# Altium schematic sheet PWRMOD-001-RevA.SchDoc
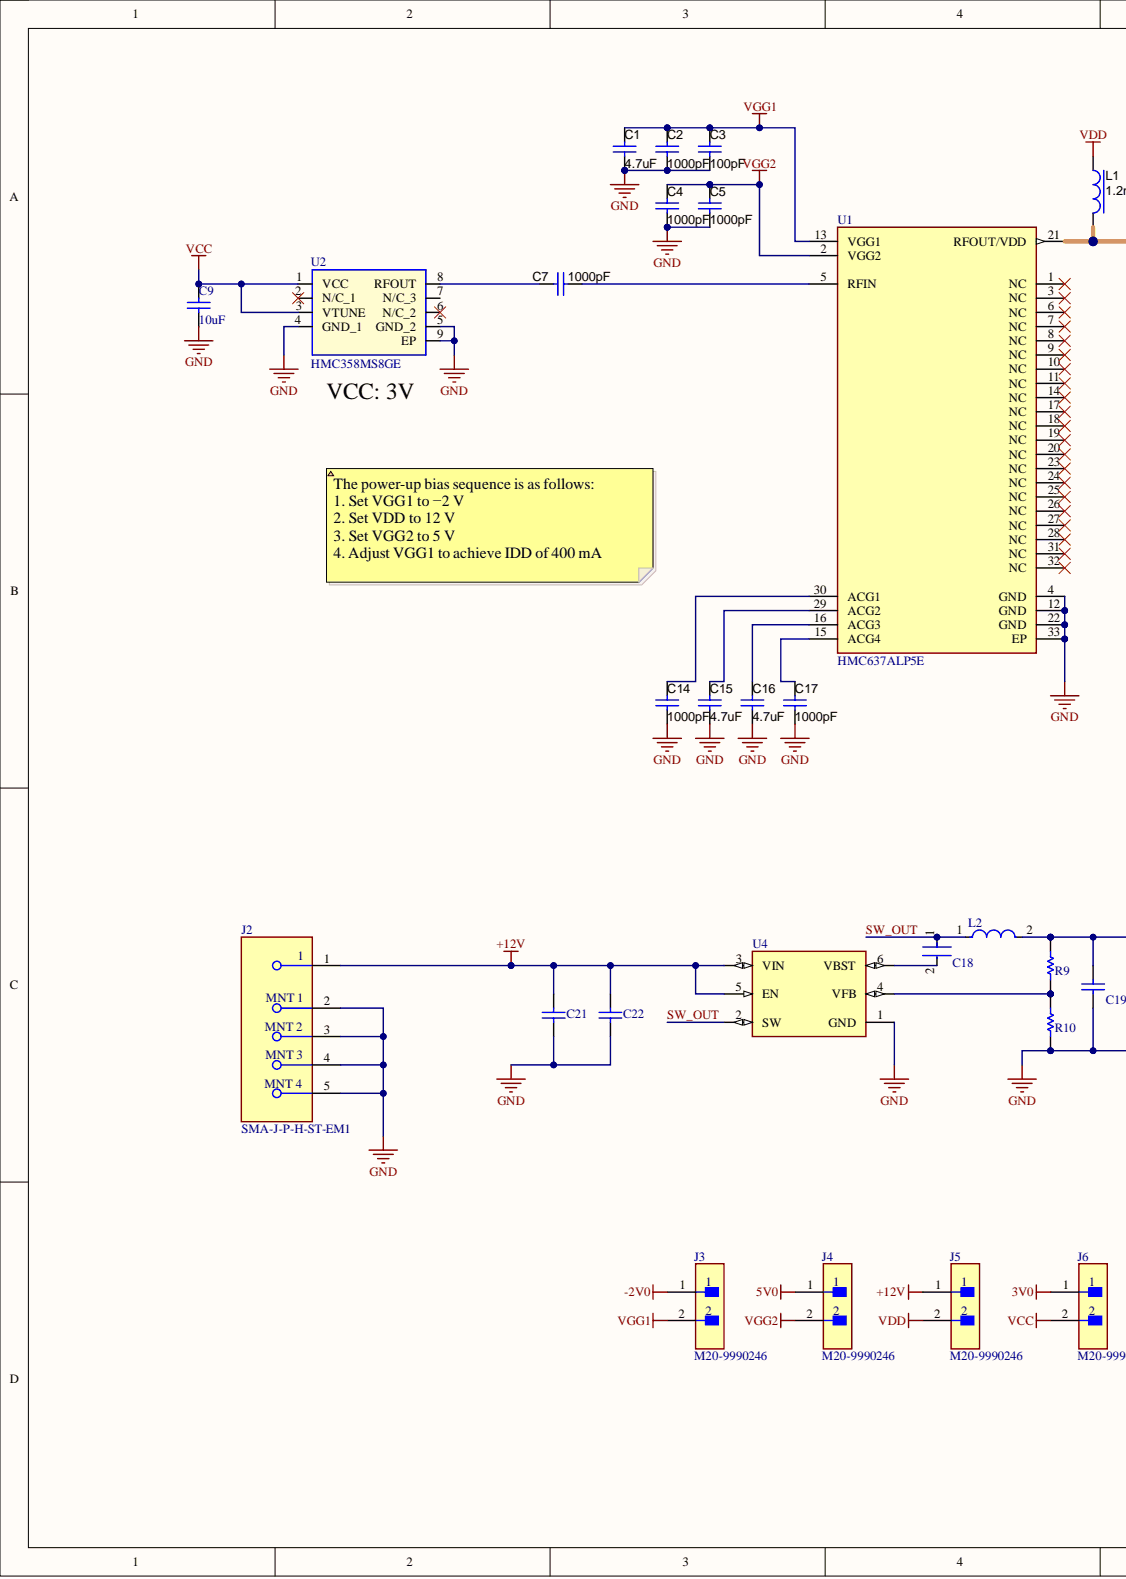
[diagram p0: PWRMOD-001-RevA.SchDoc, left]
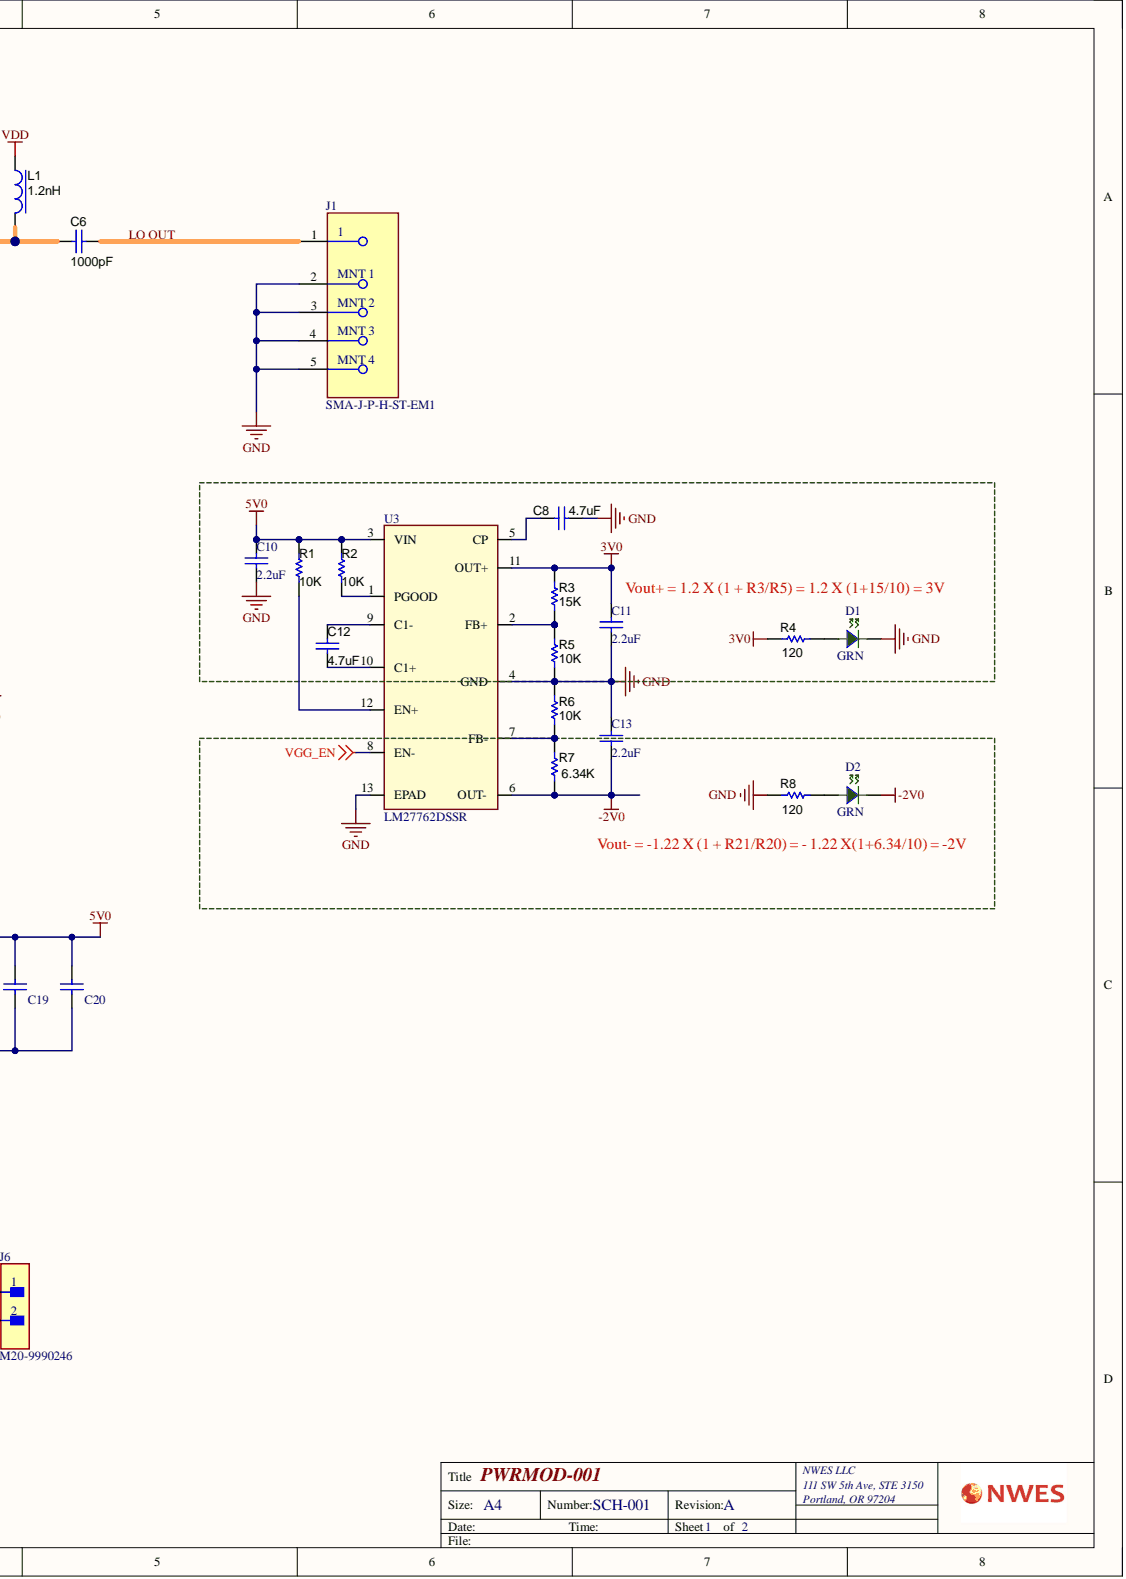
[diagram p1: PWRMOD-001-RevA.SchDoc, right]

## Parts
C1  4.7uF  [0402_CAP]
C2  1000pF  [0402_CAP]
C3  100pF  [0402_CAP]
C4  1000pF  [0402_CAP]
C5  1000pF  [0402_CAP]
C6  1000pF  [0402_CAP]
C7  1000pF  [0402_CAP]
C8  4.7uF  [0402_CAP]
C9  10uF  [0402_CAP]
C10  2.2uF  [0402_CAP]
C11  2.2uF  [0402_CAP]
C12  4.7uF  [0402_CAP]
C13  2.2uF  [0402_CAP]
C14  1000pF  [0402_CAP]
C15  4.7uF  [0402_CAP]
C16  4.7uF  [0402_CAP]
C17  1000pF  [0402_CAP]
C18  C0603C104Z3VACTU  [FP-C0603-CG-IPC_B]  (value not drawn)
C19  C1608X5R1A226M080AC  [CAPC1608X100X20ML10]  (value not drawn)
C20  C1608X5R1A226M080AC  [CAPC1608X100X20ML10]  (value not drawn)
C21  GRM31CR61E106KA12L  [CAPC3216X180X55ML20T25]  (value not drawn)
C22  GRM31CR61E106KA12L  [CAPC3216X180X55ML20T25]  (value not drawn)
D1  GRN  [LED_0603]
D2  GRN  [LED_0603]
J1  SMA-J-P-H-ST-EM1  [FP-SMA-J-P-H-ST-EM1-MFG]
J2  SMA-J-P-H-ST-EM1  [FP-SMA-J-P-H-ST-EM1-MFG]
J3  M20-9990246  [FP-M20-9990246-MFG]
J4  M20-9990246  [FP-M20-9990246-MFG]
J5  M20-9990246  [FP-M20-9990246-MFG]
J6  M20-9990246  [FP-M20-9990246-MFG]
L1  1.2nH  [IND_0201]
L2  NRS8030T3R3MJGJ  [FP-NRS8030-MFG]  (value not drawn)
R1  10K  [0402_RES]
R2  10K  [0402_RES]
R3  15K  [0402_RES]
R4  120  [0402_RES]
R5  10K  [0402_RES]
R6  10K  [0402_RES]
R7  6.34K  [0402_RES]
R8  120  [0402_RES]
R9  CRCW020156K2FNED  [RESC0603X28X15NL05T05]  (value not drawn)
R10  ERJ2RKF1002X  [RESC1005X40X25LL05T05]  (value not drawn)
U1  HMC637ALP5E  [FP-HCP-32-1-IPC_A]
U2  HMC358MS8GE  [SOP65P488X110-9N]
U3  LM27762DSSR  [SON50P200X300X80-13N-D]
U4  TPS562201DDCR  [FP-DDC0006A-IPC_C]  (value not drawn)

## Nets
3V0: C11.2 J6.1 R3.1 R4.1 U3.11(OUT+)
5V0: C10.2 C19.2 C20.2 J4.1 L2.2 R1.1 R2.1 R9.2 U3.3(VIN)
+12V: C21.2 C22.2 J2.1 J5.1 U4.3(VIN) U4.5(EN)
-2V0: C13.1 D2.2 J3.1 R7.2 U3.6(OUT-)
GND: C1.1 C2.1 C3.1 C4.1 C5.1 C8.2 C9.1 C10.1 C11.1 C13.2 C14.1 C15.1 C16.1 C17.1 C19.1 C20.1 C21.1 C22.1 D1.2 J1.2 J1.3 J1.4 J1.5 J2.2 J2.3 J2.4 J2.5 R5.2 R6.1 R8.1 R10.1 U1.4(GND) U1.12(GND) U1.22(GND) U1.33(EP) U2.4(GND_1) U2.5(GND_2) U2.9(EP) U3.4(GND) U3.13(EPAD) U4.1(GND)
VCC: C9.2 J6.2 U2.1(VCC) U2.3(VTUNE)
VDD: J5.2 L1.1
VGG1: C1.2 C2.2 C3.2 J3.2 U1.13(VGG1)
VGG2: C4.2 C5.2 J4.2 U1.2(VGG2)
VGG_EN: U3.8(EN-)
LO OUT: C6.1 J1.1
SW_OUT: C18.1 L2.1 U4.2(SW)
NetC6_2: C6.2 L1.2 U1.21(RFOUT/VDD)
NetC7_1: C7.1 U2.8(RFOUT)
NetC7_2: C7.2 U1.5(RFIN)
NetC8_1: C8.1 U3.5(CP)
NetC12_1: C12.1 U3.9(C1-)
NetC12_2: C12.2 U3.10(C1+)
NetC14_2: C14.2 U1.30(ACG1)
NetC15_2: C15.2 U1.29(ACG2)
NetC16_2: C16.2 U1.16(ACG3)
NetC17_2: C17.2 U1.15(ACG4)
NetC18_2: C18.2 U4.6(VBST)
NetD1_1: D1.1 R4.2
NetD2_1: D2.1 R8.2
NetR1_2: R1.2 U3.12(EN+)
NetR2_2: R2.2 U3.1(PGOOD)
NetR3_2: R3.2 R5.1 U3.2(FB+)
NetR6_2: R6.2 R7.1 U3.7(FB-)
NetR9_1: R9.1 R10.2 U4.4(VFB)

Not connected: U1 1(NC) 3(NC) 6(NC) 7(NC) 8(NC) 9(NC) 10(NC) 11(NC) 14(NC) 17(NC) 18(NC) 19(NC) 20(NC) 23(NC) 24(NC) 25(NC) 26(NC) 27(NC) 28(NC) 31(NC) 32(NC); U2 2(N/C_1) 6(N/C_2) 7(N/C_3)

## Library data of the parts
C1 C8 C12 C15 C16 = CAP CER 4.7UF 10V X5R 0402: Manufacturer: Samsung Electro-Mechanics; PartNumber: CL05A475MP5NRNC; Component Kind: Standard; ComponentLink1Description: Datasheet link; ComponentLink1URL: http://ds.yuden.co.jp/TYCOMPAS/ut/detail.do?productNo=LMK105BJ104KV-F&fileName=LMK105BJ104KV-F_SS&mode=specSheetDownload; Description: CAP CER 4.7UF 10V X5R 0402; PackageReference: 0402; Supplier 1: Digi-Key; Supplier Part Number 1: 1276-1482-1-ND; Technology: Ceramic; Value: 4.7uF; Library Name: Cold Junction.SCHLIB
C2 C4-C6 C14 C17 = Capacitor: CAP CER 1000PF 25V X7R 0402; Manufacturer: KEMET; Manufacturer Part Number: C0402C102J3RECAUTO; TaMax_C: 85°C; TaMin_C: -55°C; Component Kind: Standard; ComponentLink1Description: Datasheet link; ComponentLink1URL: http://ds.yuden.co.jp/TYCOMPAS/ut/detail.do?productNo=LMK105BJ104KV-F&fileName=LMK105BJ104KV-F_SS&mode=specSheetDownload; CompType: Capacitor; PackageReference: 0402; Supplier 1: Digi-Key; Technology: Ceramic; Value: 1000pF; Library Name: Cold Junction.SCHLIB
C3 = Capacitor: CAP CER 100PF 25V X7R 0402; Manufacturer: AVX Corporation; PartNumber: 04023C101KAT2A; TaMax_C: 85°C; TaMin_C: -55°C; Component Kind: Standard; ComponentLink1Description: Datasheet link; ComponentLink1URL: http://ds.yuden.co.jp/TYCOMPAS/ut/detail.do?productNo=LMK105BJ104KV-F&fileName=LMK105BJ104KV-F_SS&mode=specSheetDownload; CompType: Capacitor; PackageReference: 0402; Supplier 1: Digi-Key; Supplier Part Number 1: 478-10080-2-ND; Technology: Ceramic; Value: 100pF; Library Name: Cold Junction.SCHLIB
C7 = Capacitor: CAP CER 1000PF 50V C0G/NP0 0402; Manufacturer: Murata Electronics; PartNumber: GCM1555C1H102FA16D; TaMax_C: 85°C; TaMin_C: -55°C; Component Kind: Standard; ComponentLink1Description: Datasheet link; ComponentLink1URL: http://ds.yuden.co.jp/TYCOMPAS/ut/detail.do?productNo=LMK105BJ104KV-F&fileName=LMK105BJ104KV-F_SS&mode=specSheetDownload; CompType: Capacitor; PackageReference: 0402; Supplier 1: Digi-Key; Supplier Part Number 1: 490-16461-2-ND; Technology: Ceramic; Value: 1000pF; Library Name: Cold Junction.SCHLIB
C9 = Cap: CAP CER 10UF 6.3V X5R 0402; Manufacturer: Murata Electronics; PartNumber: GRJ155R60J106ME11D; Component Kind: Standard; Package: 0402; Signal Integrity: Cap; Simulation: CAP; Supplier 1: Digikey; Supplier Part Number 1: 490-13211-1-ND; Value: 10uF; Library Name: Cold Junction.SCHLIB
C10 C11 C13 = Cap: CAP CER 2.2UF 16V X6S 0402; Manufacturer: TDK Corporation; PartNumber: C1005X6S1C225M050BC; Component Kind: Standard; Package: 0402; Signal Integrity: Cap; Simulation: CAP; Supplier 1: Digikey; Supplier Part Number 1: 445-9101-2-ND; Value: 2.2uF; Library Name: Cold Junction.SCHLIB
C18 = CMP-2006-03069-2: CAP CER 0.1UF 25V Y5V 0603; Capacitance: 100nF; Dielectric Material: Ceramic; Dissipation Factor: 7%; Tolerance: 80%; Case Code (Imperial): 0603; Case Code (Metric): 1608; Case/Package: 0603; Component Kind: Standard; Construction: Flat; Depth: 0.8mm; Dielectric: Y5V; Height: 0.8mm; Lead Free: Lead Free; Lead Pitch: 0.7mm; Length: 1.6mm; Library Name: Altium Content Vault; Max Operating Temperature: 85°C; Min Operating Temperature: -30°C; Mount: Surface Mount; Number of Pins: 2; Package Quantity: 1; Packaging: Tape and Reel; Radiation Hardening: No; REACH SVHC: No SVHC; RoHS Compliant: Yes; Series: C; Termination: SMD/SMT; Thickness: 0.034inch; Voltage: 25V; Voltage Rating: 25V; Voltage Rating (DC): 25V; Width: 0.031inch
C19 C20 = CMP-2000-05441-1: Chip Capacitor, 22 uF, +/- 20%, 10 V, -55 to 85 degC, 0603 (1608 Metric), RoHS, Tape and Reel; Manufacturer: TDK; PCN Part Number: MLCCPartNumberChange30/Nov/2012; Capacitance: 22µF; Design Resources: 20WNon-IsolatedBuckLEDDriverUsingPLLNK460KG; Kits: 445-9014-KIT-ND-KITMLCC100PF-22UF060310-50V; Online Catalog: CSeries,GeneralPurpose; Other Names: 445-9077-1; Product Training Modules: CSeriesGeneralApplicationsSEAT,CCV,andTVCLDesignToolsGuidetoReplacinganElectrolyticCapacitorwithanMLCC; Temperature Coefficient: X5R; Voltage - Rated: 10V; Applications: GeneralPurpose; Category: Capacitors; Component Kind: Standard; Datasheet URL: http://download.siliconexpert.com/pdfs/2015/4/21/15/54/45/779/tdk_/manual/37024850441143360mlcc_commercial_general_en.pdf; Datasheet Version: Mar-15; Family: CeramicCapacitors; Features: -; Height - Seated (Max): -; Lead Spacing: -; Lead Style: -; Library Name: Altium Content Vault; Manufacturer URL: http://www.tdk.com/; Mounting Type: SurfaceMount,MLCC; Operating Temperature: -55degC~85degC; Package / Case: 0603(1608Metric); Package Description: 2-Pin Surface Mount Device, Body 1.6 x 0.8 mm; Package Reference: 603; Packaging: CutTape(CT); Ratings: -; RoHS Information: RoHSCertificate-MLCC; Series: C; Size / Dimension: 0.063"Lx0.031"W(1.60mmx0.80mm); Standard Package: 1; Thickness (Max): 0.035"(0.90mm); Tolerance: ±20%
C21 C22 = CMP-2000-04947-1: Chip Capacitor, 10 uF, +/- 10%, 25 V, -55 to 85 degC, 1206 (3216 Metric), RoHS, Tape and Reel; Manufacturer: Murata; Brand: MurataElectronics; Capacitance: 10uF; Case Height: 1.6mm; Case Length: 3.2mm; Dielectric: X5R; Type: GeneralPurposeMLCC; Voltage Rating DC: 25V; Case Code - in: 1206; Case Code - mm: 3216; Case Width: 1.6mm; Component Kind: Standard; Datasheet URL: https://datasheet.ciiva.com/11786/0900766b80ef921d-11786983.pdf; Datasheet Version: Sep-13; Factory Pack Quantity: 2000; Library Name: Altium Content Vault; Manufacturer URL: http://www.murata.com/; Maximum Operating Temperature: +85C; Minimum Operating Temperature: -55C; Package / Case: 1206(3216metric); Package Description: 2-Pin Surface Mount Device, Body 3.2 x 1.6 mm; Package Reference: 1206; Packaging: Reel; Product: GeneralTypeMLCCs; Product Category: MultilayerCeramicCapacitorsMLCC-SMD/SMT; RoHS: Details; Series: GRM; Termination Style: SMD/SMT; Tolerance: 10%
D1 D2 = 0603LED_GRN: LED GREEN CLEAR CHIP 0603 SMD; Manufacturer: Kingbright; PartNumber: APTD1608CGCK; Unit Cost, Qty 1: $0.68; Unit Cost, Qty 500: $0.293; Component Kind: Standard; Supplier 1: Digi-Key; Supplier Part Number 1: 754-1545-2-ND; Value: GRN; Library Name: Cold Junction.SCHLIB
J1 J2 = CMP-02754-000006-1: CONN SMA JACK STR 50OHM EDGE MNT; Body Material: Brass; Body Plating: Gold; Dielectric Material: PTFE; Impedance: 50R; Max Frequency: 6GHz; Voltage Rating: 335V; Case/Package: SMA; Center Contact Plating: Gold; Component Kind: Standard; Connector Type: SMA; Contact Material: Copper; Contact Plating: Gold; Depth: 13.33mm; Fastening Type: Threaded; Housing Color: Gold; Insulation Material: Polytetrafluoroethylene; Length: 6.35mm; Library Name: Altium Content Vault; Manufacturer Part Number: SMA-J-P-H-ST-EM1; Max Operating Temperature: 125°C; Min Operating Temperature: -65°C; Mount: Edge; Orientation: Straight; Package Quantity: 1; Packaging: Tray; Radiation Hardening: No; RoHS Compliant: Yes; Series: SMA; Termination: Solder
J3-J6 = CMP-15831-000009-1: CONN HEADER VERT 2POS 2.54MM; Manufacturer: Harwin; Conn Gender: Male; Conn Orientation: Vertical; Current Rating: 3A; Number Of Contacts: 2; Automotive: No; Category: Conn; Component Kind: Standard; ComponentLink2Description: Datasheet; ComponentLink2URL: https://cdn.harwin.com/pdfs/M20-999.pdf; Contact Material: Tin,Nickel; Device Class L1: Connectors; Device Class L2: Headers and Wire Housings; Device Class L3: unset; Digikey Description: CONN HEADER VERT 2POS 2.54MM; Height: 8.99mm; Lead Free: Yes; Library Name: Altium Content Vault; Manufacturer Part Number: M20-9990246; Mouser Description: 2.54mm (0.1") Pitch SIL Vertical PC Tail Pin Header, 6.1mm mating pin height, tin, 2 contacts; Number Of Rows: 1; Octopart: https://octopart.com/m20-9990246-harwin-2590635; Package: M20-9990246; Pitch: 2.54mm; RoHS: TRUE; Temperature: -40°C to +105°C; Temperature Range High: +105°C; Temperature Range Low: -40°C
L1 = INDUCTOR: FIXED IND 1.2NH 1.1A 40MOHM SMD; Manufacturer: Murata Electronics; PartNumber: LQP03HQ1N2B02D; Component Kind: Standard; ComponentLink1Description: Datasheet link; ComponentLink1URL: http://www.yuden.co.jp/ut/product/category/inductor/BRC2012T2R2MD.pdf; CompType: Inductor; Supplier 1: Digi-Key; Supplier Part Number 1: 490-13874-1-ND; Value: 1.2nH; Library Name: Cold Junction.SCHLIB
L2 = CMP-14484-000405-1: Inductor Power Shielded Wirewound 3.3uH 20% 100KHz Ferrite 4.2A 24.7mΩ DCR T/R; Current Rating: 4.3A; DC Resistance (DCR): 19mR; Self Resonant Frequency: 50MHz; Case/Package: Nonstandard; Component Kind: Standard; Core Material: Ferrite; Depth: 8.2mm; Halogen Free: Halogen Free; Height: 3mm; Height - Seated (Max): 0.118inch; Inductance: 3.3uH; Inductance Tolerance: 20%; Lead Free: Lead Free; Lead Pitch: 5.6mm; Length: 8mm; Library Name: Altium Content Vault; Max DC Current: 4.2A; Max Operating Temperature: 125°C; Min Operating Temperature: -25°C; Mount: Surface Mount; Number of Pins: 2; Package Quantity: 1000; Packaging: Tape and Reel; Radiation Hardening: No; REACH SVHC: No SVHC; Resistor Type: Wirewound; RMS Current (Irms): 4.3A; RoHS Compliant: Yes; Saturation Current: 4.2A; Series: NRS; Shielding: Shielded; Termination: SMD/SMT; Test Frequency: 100kHz; Tolerance: 20%; Width: 0.315inch
R1 R2 R5 R6 = CMP-0026823-3: RES 10K OHM 1% 1/16W 0402; Manufacturer: Stackpole Electronics Inc; PartNumber: RMCF0402FT10K0; CompType: Resistor; Component Kind: Standard; ComponentLink1Description: Datasheet link; ComponentLink1URL: http://www.vishay.com/docs/20035/dcrcwe3.pdf; MountType: SMT; PackageReference: 0402; Supplier 1: Digi-Key; Supplier Part Number 1: RMCF0402FT10K0TR-ND; Technology: Thick Film; Value: 10K; ValueDisplayed: 10K; Library Name: Cold Junction.IntLib
R3 = CMP-0026823-3: RES SMD 15K OHM 1% 1/16W 0402; Manufacturer: Yageo; PartNumber: RC0402FR-0715KL; CompType: Resistor; Component Kind: Standard; ComponentLink1Description: Datasheet link; ComponentLink1URL: http://www.vishay.com/docs/20035/dcrcwe3.pdf; MountType: SMT; PackageReference: 0402; Supplier 1: Digi-Key; Supplier Part Number 1: 311-15.0KLRTR-ND; Technology: Thick Film; Value: 15K; ValueDisplayed: 15K; Library Name: Cold Junction.IntLib
R4 R8 = RES 120 OHM 1% 1/16W 0402: Manufacturer: Yageo; PartNumber: RC0402FR-07120RL; CompType: Resistor; Component Kind: Standard; ComponentLink1Description: Datasheet link; ComponentLink1URL: http://www.vishay.com/docs/20035/dcrcwe3.pdf; Description: RES 120 OHM 1% 1/16W 0402; MountType: SMT; PackageReference: 0402; Supplier 1: Digi-Key; Supplier Part Number 1: 311-120LRCT-ND; Technology: Thick Film; Tolerance_%: 1%; Value: 120; Library Name: Cold Junction.SCHLIB
R7 = RES 6.34K OHM 1% 1/16W 0402: Manufacturer: Yageo; PartNumber: RC0402FR-076K34L; CompType: Resistor; Component Kind: Standard; ComponentLink1Description: Datasheet link; ComponentLink1URL: http://www.vishay.com/docs/20035/dcrcwe3.pdf; Description: RES 6.34K OHM 1% 1/16W 0402; MountType: SMT; PackageReference: 0402; Supplier 1: Digi-Key; Supplier Part Number 1: YAG3218CT-ND; Technology: Thick Film; Tolerance_%: 1%; Value: 6.34K; Library Name: Cold Junction.SCHLIB
R9 = CMP-2004-00723-1: Manufacturer: Vishay Dale; Component Kind: Standard; Library Name: Altium Content Vault; Part Number: CRCW020156K2FNED
R10 = CMP-2000-07588-1: Chip Resistor, 10 KOhm, +/- 1%, 0.1 W, -55 to 155 degC, 0402 (1005 Metric), RoHS, Tape and Reel; Manufacturer: Panasonic; Case\/Package: 0402; Max Power Dissipation: 100mW; Resistance: 10KOhm; Temperature Coefficient: 100ppm\/degreesC; Voltage Rating: 50V; Case Code (Imperial): 0402; Case Code (Metric): 1005; Component Kind: Standard; Composition: ThickFilm; Contact Plating: Tin; Datasheet URL: https://industrial.panasonic.com/cdbs/www-data/pdf/RDA0000/AOA0000C304.pdf; Datasheet Version: 02/2017; Depth: 0.5mm; Height: 0.35mm; Lead Free: LeadFree; Length: 1mm; Library Name: Altium Content Vault; Manufacturer URL: http://www.panasonic.com/; Max Operating Temperature: 155degC; Military Standard: Not; Min Operating Temperature: -55degC; Mount: SurfaceMount; Mounting Technology: SurfaceMount; Number of Pins: 2; Number of Terminations: 2; Package Description: 2-Pin Surface Mount Device, Body 1 x 0.5 mm; Package Quantity: 1; Package Reference: 402; Packaging: TapeandReel; Power Rating: 100mW; Radiation Hardening: No; REACH SVHC: NoSVHC; RoHSCompliant: True; Termination: SMD/SMT; Tolerance: 1%; Voltage Rating (DC): 50V; Width: 0.02inch
U1 = CMP-09142-000117-1: IC MMIC MESFET GAAS 1W 32-QFN; Gain: 13dB; Max Power Dissipation: 8.6W; Max Supply Voltage: 14V; Noise Figure: 5dB; Number of Channels: 1; Operating Supply Current: 400mA; Operating Supply Voltage: 12V; P1dB: 29dBm; Case/Package: QFN; Component Kind: Standard; Contact Plating: Tin; Lead Free: Contains Lead; Library Name: Altium Content Vault; Manufacturer Part Number: HMC637ALP5E; Max Operating Temperature: 85°C; Min Operating Temperature: -40°C; Mount: Surface Mount; Number of Pins: 32; Package Quantity: 50; Packaging: Tray; RoHS Compliant: No; Weight: 0.006653oz
U2 = HMC358MS8GE: IC MMIC AMP VCO BUFFER 8-MSOP; Manufacturer: Analog Devices; Geometry.Height: 1.1mm; Component Kind: Standard; PartNumber: HMC358MS8GE; Supplier 1: Digi-Key; Supplier Part Number 1: 1127-1344-ND; Library Name: Cold Junction.SCHLIB
U3 = LM27762DSSR: LM27762 LOW-NOISE POSITIVE- AND; Manufacturer: Texas Instruments; Geometry.Height: 0.8mm; Component Kind: Standard; Manufacturer Part Number: LM27762DSSR; Mouser Part Number: 595-LM27762DSSR; Supplier 1: Digi-Key; Supplier Part Number 1: 2156-LM27762DSSR-ND; Library Name: Cold Junction.SCHLIB
U4 = CMP-04918-000361-1: IC REG BUCK ADJ 2A TSOT23-6; Manufacturer: Texas Instruments; Max Input Voltage: 17V; Min Input Voltage: 4.5V; Number of Outputs: 1; Output Type: Adjustable; Output Voltage: 7V; Quiescent Current: 400uA; Switching Frequency: 580kHz; Case/Package: SOT; Component Kind: Standard; Contact Plating: Tin; Lead Free: Lead Free; Library Name: Altium Content Vault; Manufacturer Part Number: TPS562201DDCR; Max Operating Temperature: 125°C; Max Output Current: 2A; Min Operating Temperature: -40°C; Mount: Surface Mount; Number of Pins: 6; Operating Supply Voltage: 17V; RoHS Compliant: Yes
